# Altium schematic sheet HF_AMP.SchDoc
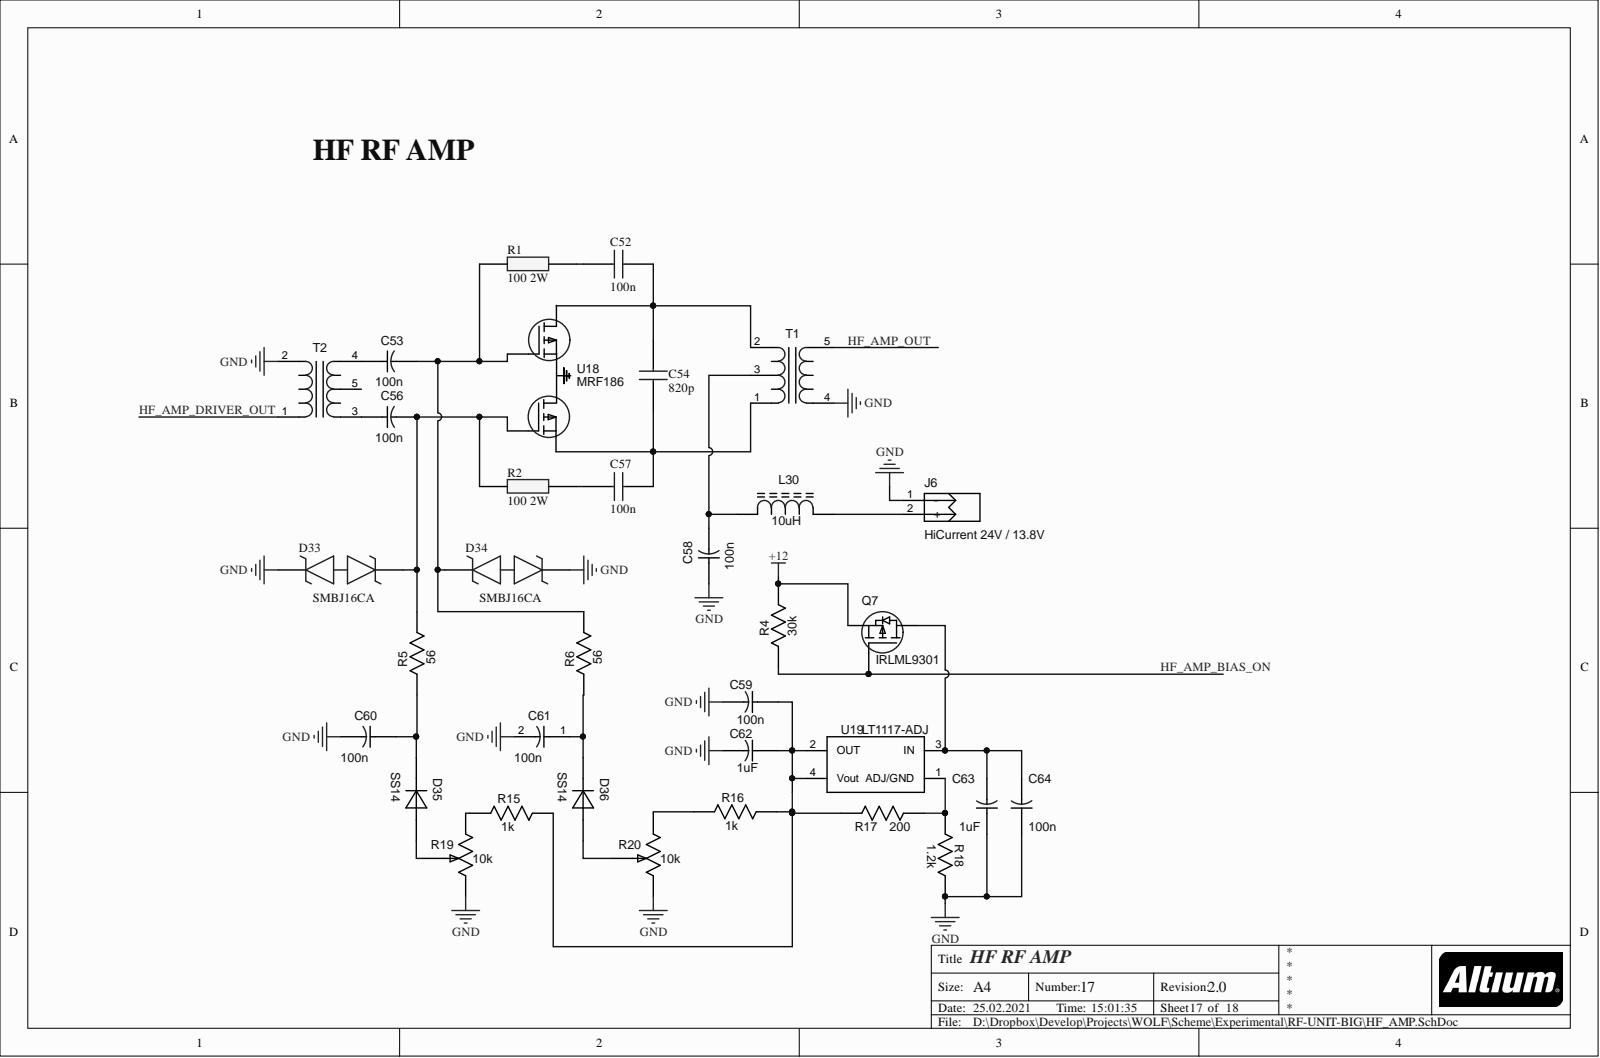
[diagram p0: HF_AMP.SchDoc]

## Parts
C52  100n  [B32620A0682J000]
C53  100n  [CAP_1206]
C54  820p  [B32620A0682J000]
C56  100n  [CAP_1206]
C57  100n  [B32620A0682J000]
C58  100n  [CAP_1206]
C59  100n  [CAP_0805]
C60  100n  [CAP_0805]
C61  100n  [CAP_0805]
C62  1uF  [CAP_0805]
C63  1uF  [CAP_0805]
C64  100n  [CAP_0805]
D33  SMBJ16CA  [DIONM5436X261N]
D34  SMBJ16CA  [DIONM5436X261N]
D35  SS14  [SS14]
D36  SS14  [SS14]
J6  HiCurrent 24V / 13.8V  [1986717-2]
L30  10uH  [IND_FERRITE_CORE]
Q7  IRLML9301  [SOT23]
R1  100 2W  [RES-2W]
R2  100 2W  [RES-2W]
R4  30k  [RES_0805]
R5  56  [RES_0805]
R6  56  [RES_0805]
R15  1k  [RES_0805]
R16  1k  [RES_0805]
R17  200  [RES_0805]
R18  1.2k  [RES_0805]
R19  value 10k  [306J]  (hidden comment: ADJ-10k)
R20  value 10k  [306J]  (hidden comment: ADJ-10k)
T1  TRANSF_HF2  [TRANSF_HF2]  (value not drawn)
T2  TRANSF_HF1  [TRANSF_HF1]  (value not drawn)
U18  MRF186  [MRF186]
U19  value LT1117-ADJ  [LT1117IST-ADJ]  (hidden comment: LT1117IST-ADJ)

## Nets
+12: Q7.2 R4.2
GND: C58.1 C59.2 C60.2 C61.2 C62.2 C63.1 C64.1 D33.1 D34.2 J6.1 R18.2 R19.1 R20.1 T1.4 T2.2
HF_AMP_BIAS_ON: Q7.1 R4.1
HF_AMP_DRIVER_OUT: T2.1
HF_AMP_OUT: T1.5
NetC52_1: C52.1 C54.2 T1.2 U18.3
NetC52_2: C52.2 R1.2
NetC53_1: C53.1 T2.4
NetC53_2: C53.2 D34.1 R1.1 R6.2 U18.1
NetC54_1: C54.1 C57.1 T1.1 U18.4
NetC56_1: C56.1 T2.3
NetC56_2: C56.2 D33.2 R2.1 R5.2 U18.2
NetC57_2: C57.2 R2.2
NetC58_2: C58.2 L30.1 T1.3
NetC59_1: C59.1 C62.1 R15.2 R16.2 R17.1 U19.2(OUT) U19.4(Vout)
NetC60_1: C60.1 D35.1 R5.1
NetC61_1: C61.1 D36.1 R6.1
NetC63_2: C63.2 C64.2 Q7.3 U19.3(IN)
NetD35_2: D35.2 R19.2
NetD36_2: D36.2 R20.2
NetJ6_2: J6.2 L30.2
NetR15_1: R15.1 R19.3
NetR16_1: R16.1 R20.3
NetR17_2: R17.2 R18.1 U19.1(ADJ/GND)

Not connected: T2 5

## Library data of the parts
C52 C54 C57 = B32620A0682J000: Capacitor; Manufacturer_Name: TDK; Component Kind: Standard; Datasheet Link: https://www.tdk-electronics.tdk.com/inf/20/20/db/fc_2009/MKP_B32620_621.pdf; Height: 12mm; Manufacturer_Part_Number: B32620A0682J000; Mouser Part Number: 871-B32620A682J; Mouser Price/Stock: https://www.mouser.com/Search/Refine.aspx?Keyword=871-B32620A682J
C53 C56 C58 = CAP_1206: Component Kind: Standard; Description: CAP_1206
C59-C64 = CAP_0805: Component Kind: Standard; Description: CAP_0805
  C59-C61 C64: Value: 100n
  C62 C63: Value: 1uF
D33 D34 = SMBJ16CA: TVS Diode (Bi-directional); Manufacturer_Name: Bourns; Arrow Part Number: SMBJ16CA; Arrow Price/Stock: https://www.arrow.com/en/products/smbj16ca/bourns; Component Kind: Standard; Datasheet Link: https://componentsearchengine.com/Datasheets/2/SMBJ16CA.pdf; Height: 2.61mm; Manufacturer_Part_Number: SMBJ16CA; Mouser Part Number: 652-SMBJ16CA; Mouser Price/Stock: https://www.mouser.co.uk/ProductDetail/Bourns/SMBJ16CA?qs=d7fLapxX37HIDtp0KgHIow%3D%3D
J6 = 1986717-2: JX2; Component Kind: Standard
L30 = IND_FERRITE_CORE: Inductor; Component Kind: Standard; Value: 10uH
Q7 = MOSFET_ENH_PD: MOSFET; Component Kind: Standard; Value: IRLML9301
R1 R2 = CFR200J100R: Manufacturer_Name: TE Connectivity; Arrow Part Number: CFR200J100R; Arrow Price/Stock: https://www.arrow.com/en/products/cfr200j100r/te-connectivity; Component Kind: Standard; Datasheet Link: http://www.te.com/commerce/DocumentDelivery/DDEController?Action=showdoc&DocId=Data+Sheet%7F1773195%7FE%7Fpdf%7FEnglish%7FENG_DS_1773195_E.pdf%7F1623929-2; Description: CFR200J100R; Manufacturer_Part_Number: CFR200J100R; Mouser Part Number: 279-CFR200J100R; Mouser Price/Stock: https://www.mouser.com/Search/Refine.aspx?Keyword=279-CFR200J100R; Library Name: RF-UNIT-BIG.SchLib
R4-R6 R15-R18 = RES_0805: Component Kind: Standard; Description: RES_0805
  R4: Value: 30k
  R5 R6: Value: 56
  R15 R16: Value: 1k
  R17: Value: 200
  R18: Value: 1.2k
R19 R20 = 306J: Component Kind: Standard; Description: 306J
T1 = TRANSF_RF2: Component Kind: Standard; Description: TRANSF_HF2; Library Name: RF-UNIT-BIG.SchLib
T2 = TRANSF_RF1: Component Kind: Standard; Description: TRANSF_HF1; Library Name: RF-UNIT-BIG.SchLib
D35 D36 = SS14: Component Kind: Standard; Description: SS14; Value: SS14
U18 = MRF186: Component Kind: Standard; Description: MRF186; Library Name: RF-UNIT-BIG.SchLib
U19 = LT1117IST-ADJ: Component Kind: Standard; Description: LT1117IST-ADJ
